# Altium schematic sheet ADC_board.SchDoc
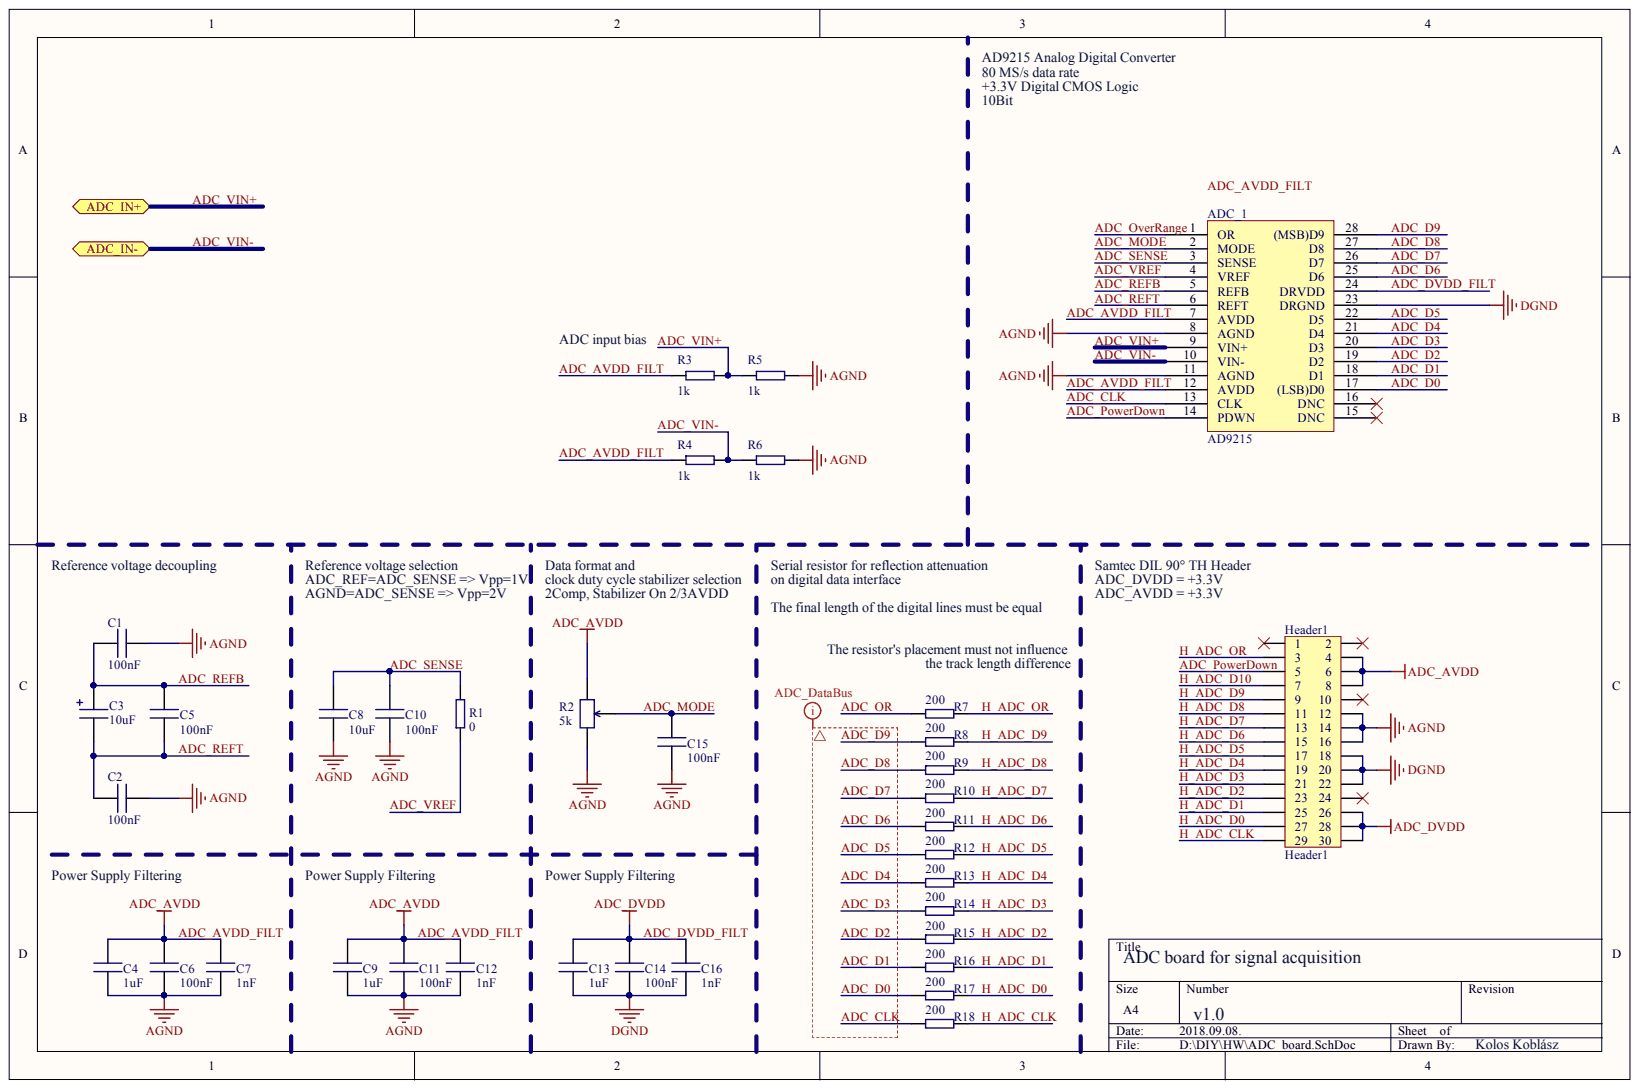
[diagram p0: ADC_board.SchDoc]

## Parts
ADC_1  AD9215  [RU-28]
C1  value 100nF  (hidden comment: Cap1)
C2  value 100nF  (hidden comment: Cap1)
C3  value 10uF  (hidden comment: Cap2)
C4  value 1uF  (hidden comment: Cap1)
C5  value 100nF  (hidden comment: Cap1)
C6  value 100nF  (hidden comment: Cap1)
C7  value 1nF  (hidden comment: Cap1)
C8  value 10uF  (hidden comment: Cap1)
C9  value 1uF  (hidden comment: Cap1)
C10  value 100nF  (hidden comment: Cap1)
C11  value 100nF  (hidden comment: Cap1)
C12  value 1nF  (hidden comment: Cap1)
C13  value 1uF  (hidden comment: Cap1)
C14  value 100nF  (hidden comment: Cap1)
C15  value 100nF  (hidden comment: Cap1)
C16  value 1nF  (hidden comment: Cap1)
Header1  Header1  [Header1]
R1  value 0  [SMD]  (hidden comment: Resistor)
R2  value 5k  [SMD]  (hidden comment: VariableResistor)
R3  value 1k  [SMD]  (hidden comment: Resistor)
R4  value 1k  [SMD]  (hidden comment: Resistor)
R5  value 1k  [SMD]  (hidden comment: Resistor)
R6  value 1k  [SMD]  (hidden comment: Resistor)
R7  value 200  [SMD]  (hidden comment: Resistor)
R8  value 200  [SMD]  (hidden comment: Resistor)
R9  value 200  [SMD]  (hidden comment: Resistor)
R10  value 200  [SMD]  (hidden comment: Resistor)
R11  value 200  [SMD]  (hidden comment: Resistor)
R12  value 200  [SMD]  (hidden comment: Resistor)
R13  value 200  [SMD]  (hidden comment: Resistor)
R14  value 200  [SMD]  (hidden comment: Resistor)
R15  value 200  [SMD]  (hidden comment: Resistor)
R16  value 200  [SMD]  (hidden comment: Resistor)
R17  value 200  [SMD]  (hidden comment: Resistor)
R18  value 200  [SMD]  (hidden comment: Resistor)

## Ports
ADC_IN+, ADC_IN-

## Nets
AGND: ADC_1.8(AGND) ADC_1.11(AGND) C1.1 C2.2 C4.2 C6.2 C7.2 C8.2 C9.2 C10.2 C11.2 C12.2 C15.2 Header1.12 Header1.14 Header1.16 R2.2 R5.2 R6.2
DGND: ADC_1.23(DRGND) C13.2 C14.2 C16.2 Header1.18 Header1.20 Header1.22
ADC_AVDD_FILT: ADC_1.7(AVDD) ADC_1.12(AVDD) C4.1 C6.1 C7.1 C9.1 C11.1 C12.1 Header1.4 Header1.6 Header1.8 R2.1 R3.1 R4.1
ADC_CLK: ADC_1.13(CLK) R18.1
ADC_D0: ADC_1.17((LSB)D0) R17.1
ADC_D1: ADC_1.18(D1) R16.1
ADC_D2: ADC_1.19(D2) R15.1
ADC_D3: ADC_1.20(D3) R14.1
ADC_D4: ADC_1.21(D4) R13.1
ADC_D5: ADC_1.22(D5) R12.1
ADC_D6: ADC_1.25(D6) R11.1
ADC_D7: ADC_1.26(D7) R10.1
ADC_D8: ADC_1.27(D8) R9.1
ADC_D9: ADC_1.28((MSB)D9) R8.1
ADC_DVDD_FILT: ADC_1.24(DRVDD) C13.1 C14.1 C16.1 Header1.26 Header1.28 Header1.30
ADC_MODE: ADC_1.2(MODE) C15.1 R2.3
ADC_OR: R7.1
ADC_OVERRANGE: ADC_1.1(OR)
ADC_POWERDOWN: ADC_1.14(PDWN) Header1.5
ADC_REFB: ADC_1.5(REFB) C1.2 C3.1 C5.1
ADC_REFT: ADC_1.6(REFT) C2.1 C3.2 C5.2
ADC_SENSE: ADC_1.3(SENSE) C8.1 C10.1 R1.2
ADC_VIN+: ADC_1.9(VIN+) R3.2 R5.1
ADC_VIN-: ADC_1.10(VIN-) R4.2 R6.1
ADC_VREF: ADC_1.4(VREF) R1.1
H_ADC_CLK: Header1.29 R18.2
H_ADC_D0: Header1.27 R17.2
H_ADC_D1: Header1.25 R16.2
H_ADC_D2: Header1.23 R15.2
H_ADC_D3: Header1.21 R14.2
H_ADC_D4: Header1.19 R13.2
H_ADC_D5: Header1.17 R12.2
H_ADC_D6: Header1.15 R11.2
H_ADC_D7: Header1.13 R10.2
H_ADC_D8: Header1.11 R9.2
H_ADC_D9: Header1.9 R8.2
H_ADC_D10: Header1.7
H_ADC_OR: Header1.3 R7.2
N00039:

Not connected: ADC_1 15(DNC) 16(DNC); Header1 1 2 10 24

## Library data of the parts
ADC_1 = AD9215: Analog Digital Converter; Manufacturer: Analog Devices; OrderCode: AD9215BRUZ-80; Component Kind: Standard; Library Name: RadioProjectSchLib.SchLib; PartNumber: AD9215
C1 C2 C4-C16 = Cap1: SMD Capacitor; MaxVolt: 16V; Precision: 1%; Component Kind: Standard; Library Name: RadioProjectSchLib.SchLib
C3 = Cap2: SMD Polarized Capacitor; MaxVolt: 16V; Precision: 1%; Component Kind: Standard; Library Name: RadioProjectSchLib.SchLib
Header1 = Header1: 29 Pin Header; Manufacterer: Samtec; PartNumber: TLW-115-01-G-D-002-RA; PinNumber: 29; Pitch: 2.54mm; Row: 2; Component Kind: Standard; Library Name: RadioProjectSchLib.SchLib; Type: 90°
R1 R3-R18 = Res1: SMD Resistor; MaxPower: 0.1W; Precision: 1%; Component Kind: Standard; Library Name: RadioProjectSchLib.SchLib
R2 = VarRes1: SMD Variable Resistor; MaxPower: 0.1W; Precision: 1%; Component Kind: Standard; Library Name: RadioProjectSchLib.SchLib
